# Altium schematic sheet schematic.SchDoc(schematic)
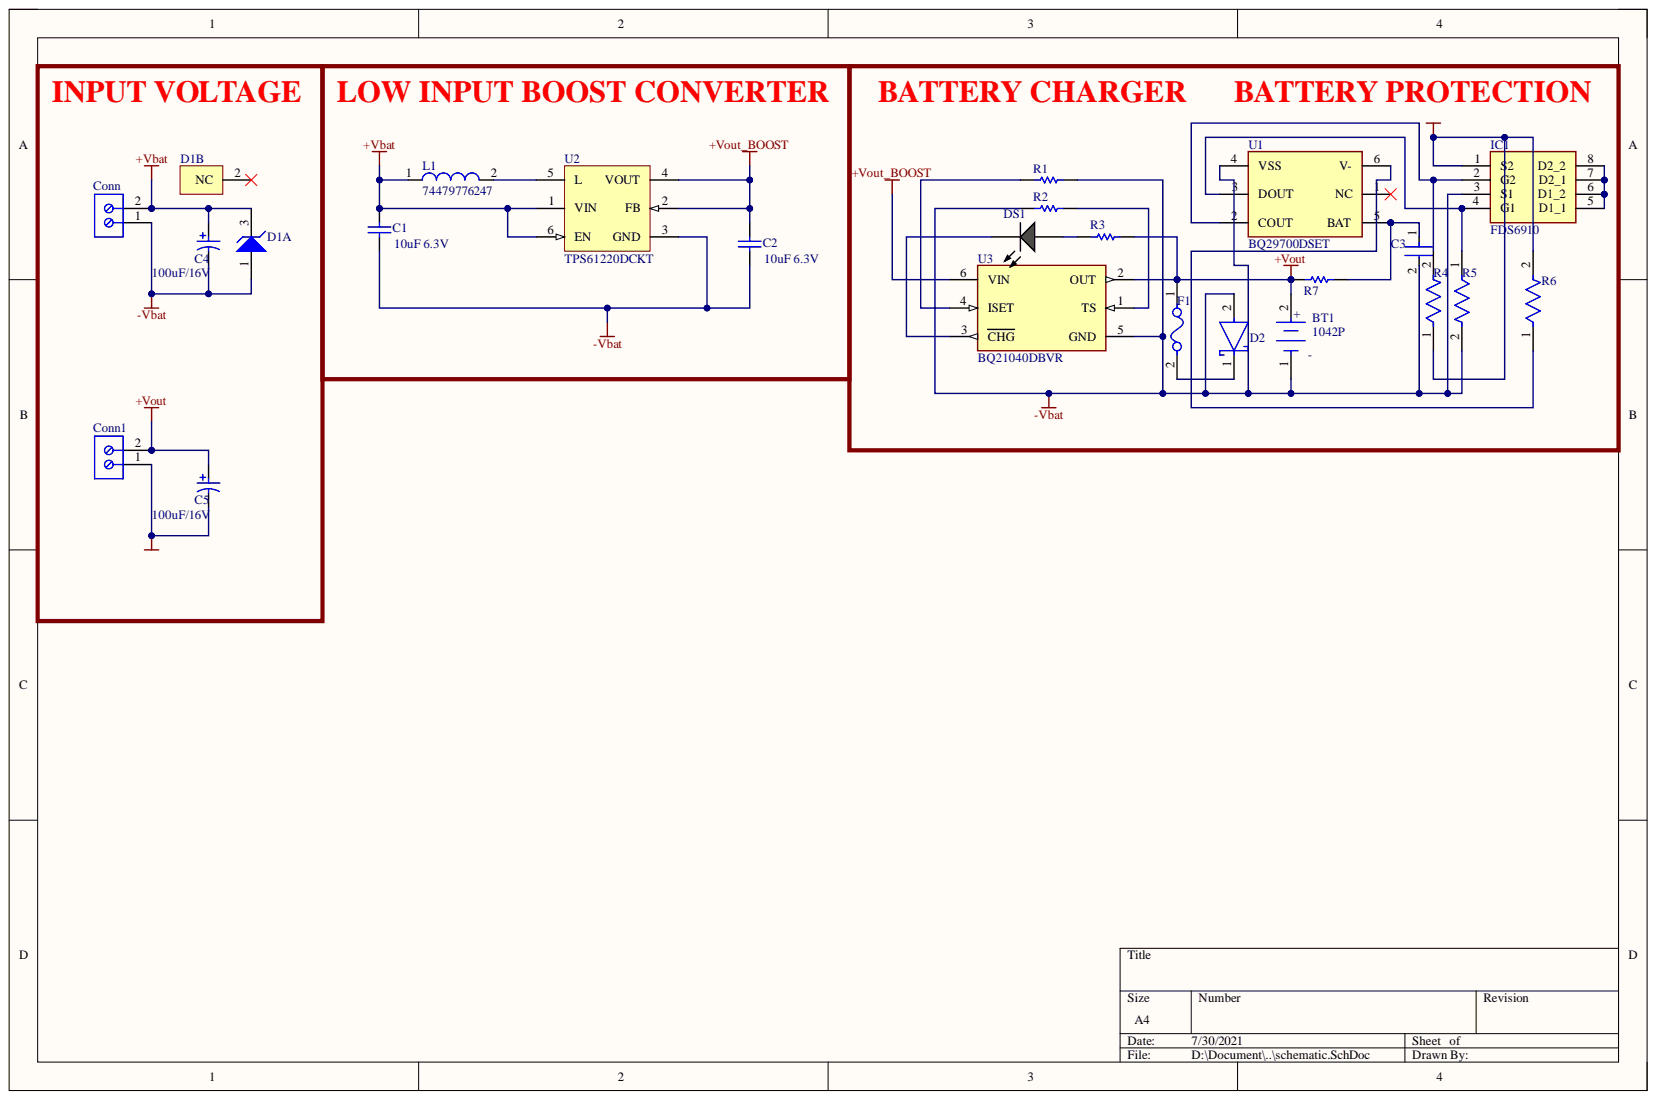
[diagram p0: schematic.SchDoc]

## Parts
BT1  1042P  [FP-1042P-MFG]
C1  10uF 6.3V  value 10uF  [CAPC0805(2012)145_L]
C2  10uF 6.3V  value 10uF  [CAPC0805(2012)145_L]
C3  CL10B104JO8NNND  [FP-CL10-IPC_B]  (value not drawn)
C4  100uF/16V  [PNSC-HA-V-D_V]
C5  100uF/16V  [PNSC-HA-V-D_V]
Conn  691103110002  [691103110002]  (value not drawn)
Conn1  691103110002  [691103110002]  (value not drawn)
D1  BZX84B5V6LT1G  [ONSC-SOT-23-3-318-08_V]  (value not drawn)  (parts A,B)
D2  MBR120VLSFT3G  [FP-498-MFG]  (value not drawn)
DS1  HLMP-1790  [LED-2P-2.54-3MM_V]  (value not drawn)
F1  0154004.DR  [FP-0154-MFG]  (value not drawn)
IC1  FDS6910  [SOIC127P600X175-8N]
L1  74479776247  [SMD 0806]
R1  RK73B2ATTD102J  [RESC2013X60X30ML20T20]  (value not drawn)
R2  ERT-J0EM103J  [RESC1005X40X25ML05T05]  (value not drawn)
R3  RK73B2ATTD102J  [RESC2013X60X30ML20T20]  (value not drawn)
R4  CRCW08054M70FKEA  [FP-CRCW0805-e3-MFG]  (value not drawn)
R5  CRCW08054M70FKEA  [FP-CRCW0805-e3-MFG]  (value not drawn)
R6  RC0603J102CS  [FP-RC0603-MFG]  (value not drawn)
R7  CRCW1210330RJNEA  [RESC3225X60X45ML20T20]  (value not drawn)
U1  BQ29700DSET  [FP-DSE0006A-MFG]
U2  TPS61220DCKT  [DCK0006A_M]
U3  BQ21040DBVR  [FP-DBV0006A-MFG]

## Nets
+Vbat: C1.1 C4.1 Conn.2 D1.3 L1.1 U2.1(VIN) U2.6(EN)
+Vout: BT1.2 C5.1 Conn1.2 F1.1 R3.2 R7.2 U3.2(OUT)
+Vout_BOOST: C2.1 U2.2(FB) U2.4(VOUT) U3.6(VIN)
-Vbat: BT1.1 C1.2 C2.2 C3.2 C4.2 Conn.1 D1.1 D2.2 IC1.3(S1) R1.2 R2.1 R5.2 U1.4(VSS) U2.3(GND) U3.5(GND)
NetC3_1: C3.1 R7.1 U1.5(BAT)
NetC5_2: C5.2 Conn1.1 IC1.1(S2) R4.1 R6.2
NetD2_1: D2.1 F1.2
NetDS1_1: DS1.1 R3.1
NetDS1_2: DS1.2 U3.3(CHG)
NetIC1_2: IC1.2(G2) R4.2 U1.2(COUT)
NetIC1_4: IC1.4(G1) R5.1 U1.3(DOUT)
NetIC1_5: IC1.5(D1_1) IC1.6(D1_2) IC1.7(D2_1) IC1.8(D2_2)
NetL1_2: L1.2 U2.5(L)
NetR1_1: R1.1 U3.4(ISET)
NetR2_2: R2.2 U3.1(TS)
NetR6_1: R6.1 U1.6(V-)

Not connected: D1 2(NC); U1 1(NC)

## Library data of the parts
BT1 = CMP-19636-000019-1: BATTERY HOLDER 18650 SMD TAB; Number of Cells: 1; Component Kind: Standard; Lead Free: Lead Free; Library Name: Altium Content Vault; Max Operating Temperature: 145°C; Min Operating Temperature: -50°C; Mount: Surface Mount; Package Quantity: 200; Packaging: Tray; Termination: SMD/SMT
C1 C2 = CMP-1036-04915-1: Case-EIA: 0805; Case-Metric: 2012; Tolerance: Â±10%; Component Kind: Standard; Description: 10uF 6.3V; Library Name: Altium Content Vault; Max Thickness: 1.45 mm; Rated Voltage: 6.3 V; Technology: SMT
C3 = CMP-13271-003283-1: Cap Ceramic 100nF 16V X7R ±5% Pad SMD 0603 +125°C T/R; Capacitance: 100nF; Case/Package: 0603; Component Kind: Standard; Depth: 0.8mm; Dielectric: X7R; Height: 0.8mm; Lead Free: Lead Free; Length: 1.6mm; Library Name: Altium Content Vault; Material: Ceramic; Max Operating Temperature: 125°C; Min Operating Temperature: -55°C; Mount: Surface Mount; Package Quantity: 10000; Packaging: Tape and Reel; Radiation Hardening: No; Thickness: 0.035inch; Tolerance: 5%; Voltage Rating: 16V; Voltage Rating (DC): 16V; Width: 0.031inch
C4 C5 = CMP-2000-06219-1: Aluminum Electrolytic Capacitor, 100 uF, +/- 20%, 16 V, 2-Pin SMD (Size Code D), -40 to 105 degC, RoHS, Tape and Reel; Manufacturer: Panasonic; Capacitance: 100µF; Lifetime@Temperature: 1000Hrs@105°C; Rated Voltage: 16V; Ripple Current: 70mA; Type: Can; Case Size/Dimension: Ø6.3x5.4mm; Component Kind: Standard; Datasheet URL: https://industrial.panasonic.com/cdbs/www-data/pdf/RDE0000/ABA0000C11.pdf; Datasheet Version: 03/2015; Library Name: Altium Content Vault; Manufacturer URL: http://www.panasonic.com/; Mounting Technology: SurfaceMount; Operating Temp Range: -40to+105°C; Operating Temp-Max: 105°C; Package Description: 2-Pin Electrolytic Aluminum Capacitor, Body 6.6 x 6.6 mm; Package Reference: HA-V-D; Package URL: http://industrial.panasonic.com/cdbs/www-data/pdf/RDE0000/DME0000COL48.pdf; Series: HA
Conn Conn1 = CMP-1502-03254-1: Series 1031 - 3.50 mm Horizontal Entry Modular with Pressure Clamp WR-TBL, 2 pin; Manufacturer: Wurth Elektronik; Pin Number: 2; Pitch: 3.50mm; Component Kind: Standard; Datasheet URL: http://katalog.we-online.de/em/datasheet/6911031100xx.pdf; Library Name: Altium Content Vault; Manufacturer URL: http://www.we-online.de; Mounting Technology: THT; Operating Temperature: -40to105degC; Package Reference: 691103110002; Packaging: Box; Part Number: 691103110002; Publisher: WurthElektronik
D1 = CMP-1060-00891-1: Zener Voltage Regulator, 225 mW, 3-Pin SOT-23, Pb-Free, Tape and Reel; Manufacturer: On Semiconductor; VZ Typ (V): 5.6; Component Kind: Standard; ComponentLink1Description: Manufacturer URL; ComponentLink1URL: http://www.onsemi.com/; ComponentLink2Description: Datasheet; ComponentLink2URL: http://www.onsemi.com/pub_link/Collateral/BZX84C2V4LT1-D.PDF; ComponentLink3Description: Package Specification; ComponentLink3URL: http://www.onsemi.cn/pub_link/Collateral/318-08.PDF; DatasheetVersion: Rev. 17; Library Name: Altium Content Vault; Mounting Technology: Surface Mount; PackageDescription: 3-Pin Small Outline Transistor, Body 2.92 x 1.3 mm, Pitch 0.95 mm; PackageReference: SOT-23-3-318-08; PackageVersion: Rev. AP, 11/2009; Packing: Tape and Reel; PartNumber: BZX84B5V6LT1G; RoHS: Pb-Free
D2 = CMP-1055-00447-2: DIODE SCHOTTKY 20V 1A SOD123FL; Element Configuration: Single; Forward Voltage: 340mV; Max Forward Surge Current (Ifsm): 45A; Max Reverse Leakage Current: 600uA; Polarity: Standard; Average Rectified Current: 1A; Component Kind: Standard; Current Rating: 1A; Forward Current: 1A; Halogen Free: Halogen Free; Lead Free: Lead Free; Library Name: Altium Content Vault; Max Operating Temperature: 125°C; Max Repetitive Reverse Voltage (Vrrm): 20V; Max Reverse Voltage (DC): 20V; Max Surge Current: 45A; Min Operating Temperature: -65°C; Number of Pins: 2; Output Current: 1A; Package Quantity: 10000; Packaging: Tape and Reel; Peak Non-Repetitive Surge Current: 45A; Peak Reverse Current: 600uA; Radiation Hardening: No; REACH SVHC: No SVHC; Voltage Rating (DC): 20V
DS1 = CMP-2000-05186-1: LED, Green, 2 mA, 1.9 V, -20 to 100 degC, 2-Pin THD, RoHS, Bulk; Manufacturer: Avago; Dominant Wavelength/Color Temperature: 569nm; Intensity: 2.3mcd; Lens Appearance: ColoredDiffused; Lens Shape Type: Circular; Mounting Orientation: TopMount; Number of LEDs: 1; Peak Wavelength: 565nm; Rad Hard: No; Type: Uni-Color; Viewing Angle: 50deg; Category: LEDs; Color: Green; Component Kind: Standard; Datasheet URL: https://datasheet.ciiva.com/11773/0900766b800204ad-11773539.pdf; Datasheet Version: Jul-14; Library Name: Altium Content Vault; Manufacturer URL: http://www.avagotech.com/; Mounting: ThroughHole; Package: 2T-1; Packaging: Bulk; Pin Count: 2
F1 = CMP-08605-000003-1: FUSE BRD MNT 4A 125VAC/VDC 2SMD; Manufacturer: Littelfuse; Fuse Blow Speed: Fast; Max Fuse Ac Voltage: 125V; Automotive: No; Category: Fuse; Component Kind: Standard; ComponentLink2Description: Datasheet; ComponentLink2URL: https://www.littelfuse.com/~/media/electronics/datasheets/fuses/littelfuse_fuse_154_154t_154l_154tl_datasheet.pdf.pdf; Device Class L1: Circuit Protection; Device Class L2: Fuses; Device Class L3: unset; Digikey Description: FUSE BRD MNT 4A 125VAC/VDC 2SMD; Fuse Current: 4A; Height: 3.81mm; Lead Free: Yes; Library Name: Altium Content Vault; Manufacturer Part Number: 0154004.DR; Max Fuse Dc Voltage: 125V; Mouser Description: Surface Mount Fuses Fuseblock w/ fuse 4A OMNI BLOK 154; Octopart: https://octopart.com/0154004.dr-littelfuse-565861; Package: 0154; RoHS: TRUE; Temperature: -55°C to +125°C; Temperature Range High: +125°C; Temperature Range Low: -55°C
IC1 = FDS6910: Integrated Circuit; Manufacturer_Name: ON Semiconductor; Arrow Part Number: FDS6910; Arrow Price/Stock: https://www.arrow.com/en/products/fds6910/on-semiconductor; Component Kind: Standard; Datasheet Link: http://docs-emea.rs-online.com/webdocs/0d23/0900766b80d235ce.pdf; Height: 1.75mm; Manufacturer_Part_Number: FDS6910; Mouser Part Number: 512-FDS6910; Mouser Price/Stock: https://www.mouser.co.uk/ProductDetail/ON-Semiconductor-Fairchild/FDS6910?qs=e8vIWuFTP5FNRni%2F3w%2FE5g%3D%3D
L1 = CMP-1392-00053-1: Power Multilayer Inductor WE-PMI, L=4.70 µH; Manufacturer: Wuerth Elektronik; Inductance: 4.70 ÂµH; Component Kind: Standard; ComponentLink1Description: Manufacturer URL; ComponentLink1URL: http://www.we-online.com; ComponentLink2Description: Datasheet; ComponentLink2URL: http://katalog.we-online.de/kataloge/eisos/media/pdf/74479776247A.pdf; Library Name: Altium Content Vault; PackageReference: SMD 0806; PartNumber: 74479776247
R1 R3 = CMP-2001-04656-1: Manufacturer: KOA Speer; Component Kind: Standard; Library Name: Altium Content Vault; Part Number: RK73B2ATTD102J
R2 = CMP-2002-01181-1: Manufacturer: Panasonic; Component Kind: Standard; Library Name: Altium Content Vault; Part Number: ERT-J0EM103J
R4 R5 = CMP-2001-03976-2: RES Thick Film, 4.7MΩ, 1%, 0.125W, 100ppm/°C, 0805; Power Rating: 125mW; Resistance: 4.7MR; Voltage Rating: 150V; Case Code (Imperial): 0805; Case Code (Metric): 2012; Case/Package: 0805; Component Kind: Standard; Composition: Thick Film; Contact Plating: Tin; Depth: 1.25mm; Height: 0.45mm; Lead Free: Lead Free; Length: 2mm; Library Name: Altium Content Vault; Max Operating Temperature: 155°C; Military Standard: Not; Min Operating Temperature: -55°C; Number of Pins: 2; Package Quantity: 5000; Packaging: Tape and Reel; Radiation Hardening: No; Temperature Coefficient: 100ppm/°C; Termination: SMD/SMT; Tolerance: 1%; Voltage Rating (DC): 150V; Weight: 0.000194oz; Width: 1.25mm
R6 = CMP-13261-005572-1: Res Thick Film 0201 1kΩ 5% 0.05W ±250ppm/°C Molded SMD T/R; Max Power Dissipation: 50mW; Resistance: 1kR; Temperature Coefficient: 200ppm/°C; Case Code (Imperial): 0201; Case Code (Metric): 0603; Case/Package: 0201; Component Kind: Standard; Composition: Thick Film; Contact Plating: Tin; Depth: 0.3mm; Features: Moisture Resistant; Height: 0.01inch; Length: 0.024inch; Library Name: Altium Content Vault; Max Operating Temperature: 125°C; Military Standard: Not; Min Operating Temperature: -55°C; Number of Terminations: 2; Packaging: Tape and Reel; Power Rating: 50mW; Radiation Hardening: No; Tolerance: 5%; Width: 0.012inch
R7 = CMP-2005-00032-1: Manufacturer: Vishay Dale; Component Kind: Standard; Library Name: Altium Content Vault; Part Number: CRCW1210330RJNEA
U1 = CMP-04911-000007-1: IC LITHIUM BATT PROTECT 6-SON; Manufacturer: Texas Instruments; Max Supply Voltage: 28V; Min Supply Voltage: 1.5V; Nominal Supply Current: 4uA; Number Of Cells: 1; Standoff Height: 0mm; Ambient Temperature Range High: +85°C; Ambient Temperature Range Low: -40°C; Automotive: No; Category: IC; Component Kind: Standard; ComponentLink2Description: Datasheet; ComponentLink2URL: http://www.ti.com/lit/ds/symlink/bq2970.pdf; Device Class L1: Integrated Circuits (ICs); Device Class L2: Power Management ICs; Device Class L3: Battery Management; Digikey Description: IC LITHIUM BATT PROTECT 6-SON; Height: 0.8mm; Ipc Land Pattern Name: DFN150X150X75-6; Lead Free: Yes; Library Name: Altium Content Vault; Manufacturer Part Number: BQ29700DSET; Mouser Description: Battery Management Li-Ion/Li Polymer Batt protection IC; Number Of Outputs: 1; Octopart: https://octopart.com/bq29700dset-texas+instruments-34962965; Package: DSE0006A; RoHS: TRUE; Temperature: -40°C to +85°C; Temperature Range High: +85°C; Temperature Range Low: -40°C
U2 = CMP-0343-00081-2: Boost Voltage Converter with 0.7 to 5.5 V Input and 1.8 to 5.5 V Output, -40 to 85 degC, 6-Pin SC70 (DCK), Green (RoHS & no Sb/Br); Manufacturer: Texas Instruments; Regulated Outputs(#): 1; SubFamily: Step-Up Regulator; Switching Frequency(Max)(kHz): 2000; Vin(Max)(V): 5.5; Vin(Min)(V): 0.7; Vout(Min)(V): 1.8; Code_JEDEC: MO-203-AB; Component Kind: Standard; ComponentLink1Description: Datasheet; ComponentLink1URL: http://www.ti.com/general/docs/lit/getliterature.tsp?genericPartNumber=TPS61220&fileType=pdf; ComponentLink2Description: Package Specification; ComponentLink2URL: http://www.ti.com/litv/pdf/mpds114c; DatasheetVersion: SLVS776; Eco-Plan: Green (RoHS & no Sb/Br); Library Name: Altium Content Vault; Mounting Technology: Surface Mount; PackageDescription: 6-Pin Plastic Small-Outline Package, 2 x 1.3 mm Body, 0.65 mm Pitch, 2.1 mm Lead Span; PackageReference: DCK0006A; PackageVersion: revG, Jan-2007; PartNumber: TPS61220DCKT; Topology: Boost; Vout(Max)(V): 5.5
U3 = CMP-04911-000045-1: IC BATT CHG LI-ION 1CELL SOT23-6; Battery Pack Voltage: 4.2V; Differential Output: No; Fault Protection: Over Voltage; Interface: USB; Max Charge Current: 800mA; Max Supply Voltage: 6.45V; Number of Bits: 16; Number of Cells: 1; Number of D/A Converters: 4; Output Type: Analog Current/Voltage; Settling Time: 30us; Case/Package: SOT-23-6; Component Kind: Standard; Lead Free: Lead Free; Library Name: Altium Content Vault; Max Operating Temperature: 125°C; Min Operating Temperature: 0°C; Mount: Surface Mount; Packaging: Cut Tape; RoHS Compliant: Yes
